# Altium schematic sheet STM32H750XB_MEMORY.SchDoc
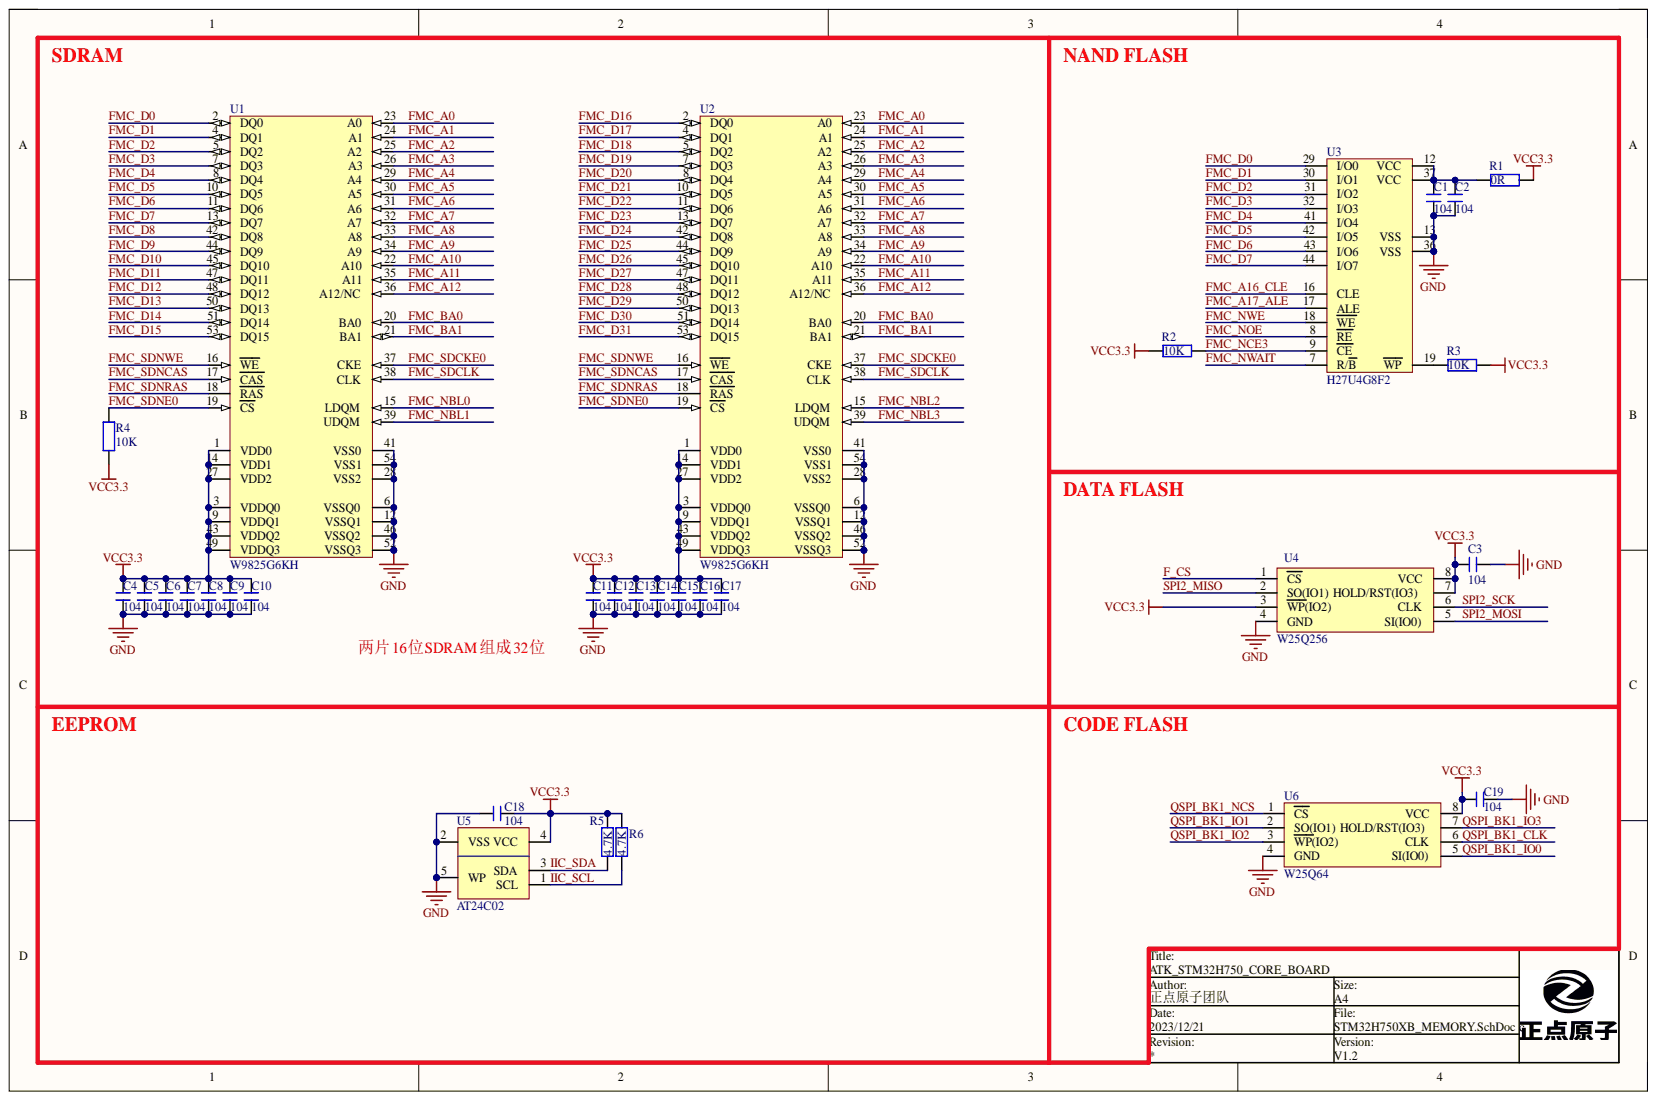
[diagram p0: STM32H750XB_MEMORY.SchDoc]

## Parts
C1  104  [C 0402]
C2  104  [C 0402]
C3  104  [C 0402]
C4  104  [C 0402]
C5  104  [C 0402]
C6  104  [C 0402]
C7  104  [C 0402]
C8  104  [C 0402]
C9  104  [C 0402]
C10  104  [C 0402]
C11  104  [C 0402]
C12  104  [C 0402]
C13  104  [C 0402]
C14  104  [C 0402]
C15  104  [C 0402]
C16  104  [C 0402]
C17  104  [C 0402]
C18  104  [C 0402]
C19  104  [C 0402]
R1  0R  [R 0402]
R2  10K  [R 0402]
R3  10K  [R 0402]
R4  10K  [R 0402]
R5  4.7K  [R 0402]
R6  4.7K  [R 0402]
U1  W9825G6KH  [TSOP54]
U2  W9825G6KH  [TSOP54]
U3  H27U4G8F2  [TSOP48]
U4  W25Q256  [WSON8]
U5  AT24C02  [SOT23-5L]
U6  W25Q64  [SOP8W_L]

## Nets
GND: C1.1 C2.1 C3.1 C4.1 C5.1 C6.1 C7.1 C8.1 C9.1 C10.1 C11.1 C12.1 C13.1 C14.1 C15.1 C16.1 C17.1 C18.1 C19.2 U1.6(VSSQ0) U1.12(VSSQ1) U1.28(VSS2) U1.41(VSS0) U1.46(VSSQ2) U1.52(VSSQ3) U1.54(VSS1) U2.6(VSSQ0) U2.12(VSSQ1) U2.28(VSS2) U2.41(VSS0) U2.46(VSSQ2) U2.52(VSSQ3) U2.54(VSS1) U3.13(VSS) U3.36(VSS) U4.4(GND) U5.2(VSS) U5.5(WP) U6.4(GND)
VCC3.3: C3.2 C4.2 C5.2 C6.2 C7.2 C8.2 C9.2 C10.2 C11.2 C12.2 C13.2 C14.2 C15.2 C16.2 C17.2 C18.2 C19.1 R1.2 R2.1 R3.2 R4.1 R5.2 R6.2 U1.1(VDD0) U1.3(VDDQ0) U1.9(VDDQ1) U1.14(VDD1) U1.27(VDD2) U1.43(VDDQ2) U1.49(VDDQ3) U2.1(VDD0) U2.3(VDDQ0) U2.9(VDDQ1) U2.14(VDD1) U2.27(VDD2) U2.43(VDDQ2) U2.49(VDDQ3) U4.3(WP(IO2)) U4.7(HOLD/RST(IO3)) U4.8(VCC) U5.4(VCC) U6.8(VCC)
F_CS: U4.1(CS)
FMC_A0: U1.23(A0) U2.23(A0)
FMC_A1: U1.24(A1) U2.24(A1)
FMC_A2: U1.25(A2) U2.25(A2)
FMC_A3: U1.26(A3) U2.26(A3)
FMC_A4: U1.29(A4) U2.29(A4)
FMC_A5: U1.30(A5) U2.30(A5)
FMC_A6: U1.31(A6) U2.31(A6)
FMC_A7: U1.32(A7) U2.32(A7)
FMC_A8: U1.33(A8) U2.33(A8)
FMC_A9: U1.34(A9) U2.34(A9)
FMC_A10: U1.22(A10) U2.22(A10)
FMC_A11: U1.35(A11) U2.35(A11)
FMC_A12: U1.36(A12/NC) U2.36(A12/NC)
FMC_A16_CLE: U3.16(CLE)
FMC_A17_ALE: U3.17(ALE)
FMC_BA0: U1.20(BA0) U2.20(BA0)
FMC_BA1: U1.21(BA1) U2.21(BA1)
FMC_D0: U1.2(DQ0) U3.29(I/O0)
FMC_D1: U1.4(DQ1) U3.30(I/O1)
FMC_D2: U1.5(DQ2) U3.31(I/O2)
FMC_D3: U1.7(DQ3) U3.32(I/O3)
FMC_D4: U1.8(DQ4) U3.41(I/O4)
FMC_D5: U1.10(DQ5) U3.42(I/O5)
FMC_D6: U1.11(DQ6) U3.43(I/O6)
FMC_D7: U1.13(DQ7) U3.44(I/O7)
FMC_D8: U1.42(DQ8)
FMC_D9: U1.44(DQ9)
FMC_D10: U1.45(DQ10)
FMC_D11: U1.47(DQ11)
FMC_D12: U1.48(DQ12)
FMC_D13: U1.50(DQ13)
FMC_D14: U1.51(DQ14)
FMC_D15: U1.53(DQ15)
FMC_D16: U2.2(DQ0)
FMC_D17: U2.4(DQ1)
FMC_D18: U2.5(DQ2)
FMC_D19: U2.7(DQ3)
FMC_D20: U2.8(DQ4)
FMC_D21: U2.10(DQ5)
FMC_D22: U2.11(DQ6)
FMC_D23: U2.13(DQ7)
FMC_D24: U2.42(DQ8)
FMC_D25: U2.44(DQ9)
FMC_D26: U2.45(DQ10)
FMC_D27: U2.47(DQ11)
FMC_D28: U2.48(DQ12)
FMC_D29: U2.50(DQ13)
FMC_D30: U2.51(DQ14)
FMC_D31: U2.53(DQ15)
FMC_NBL0: U1.15(LDQM)
FMC_NBL1: U1.39(UDQM)
FMC_NBL2: U2.15(LDQM)
FMC_NBL3: U2.39(UDQM)
FMC_NCE3: R2.2 U3.9(CE)
FMC_NOE: U3.8(RE)
FMC_NWAIT: U3.7(R/B)
FMC_NWE: U3.18(WE)
FMC_SDCKE0: U1.37(CKE) U2.37(CKE)
FMC_SDCLK: U1.38(CLK) U2.38(CLK)
FMC_SDNCAS: U1.17(CAS) U2.17(CAS)
FMC_SDNE0: R4.2 U1.19(CS) U2.19(CS)
FMC_SDNRAS: U1.18(RAS) U2.18(RAS)
FMC_SDNWE: U1.16(WE) U2.16(WE)
IIC_SCL: R6.1 U5.1(SCL)
IIC_SDA: R5.1 U5.3(SDA)
QSPI_BK1_CLK: U6.6(CLK)
QSPI_BK1_IO0: U6.5(SI(IO0))
QSPI_BK1_IO1: U6.2(SO(IO1))
QSPI_BK1_IO2: U6.3(WP(IO2))
QSPI_BK1_IO3: U6.7(HOLD/RST(IO3))
QSPI_BK1_NCS: U6.1(CS)
SPI2_MISO: U4.2(SO(IO1))
SPI2_MOSI: U4.5(SI(IO0))
SPI2_SCK: U4.6(CLK)
NetC1_2: C1.2 C2.2 R1.1 U3.12(VCC) U3.37(VCC)
NetR3_1: R3.1 U3.19(WP)

## Library data of the parts
C1-C19 = C: 无极性贴片电容; 版权归属: 源创客; BOM名称: 无极性贴片电容; Component Kind: Standard; Library Name: 电阻-电容-电感.SchLib; 学习资源: www.ooocke.com
R1-R6 = R: 贴片电阻; 版权归属: 源创客; BOM名称: 贴片电阻; Component Kind: Standard; Library Name: 电阻-电容-电感.SchLib; 学习资源: www.ooocke.com
U1 U2 = W9825G6KH: WINBOND SDRAM; Component Kind: Standard; Library Name: Lycreturn.IntLib
U3 = MT29F4G08: NAND FLASH; Component Kind: Standard; Library Name: Lycreturn.IntLib
U4 U6 = W25Q256: 外置flash; Component Kind: Standard; Library Name: Lycreturn.IntLib
U5 = AT24C02: 外置EEPROM芯片; Component Kind: Standard; Library Name: IC芯片.SchLib
